# Altium schematic sheet POWER.SchDoc
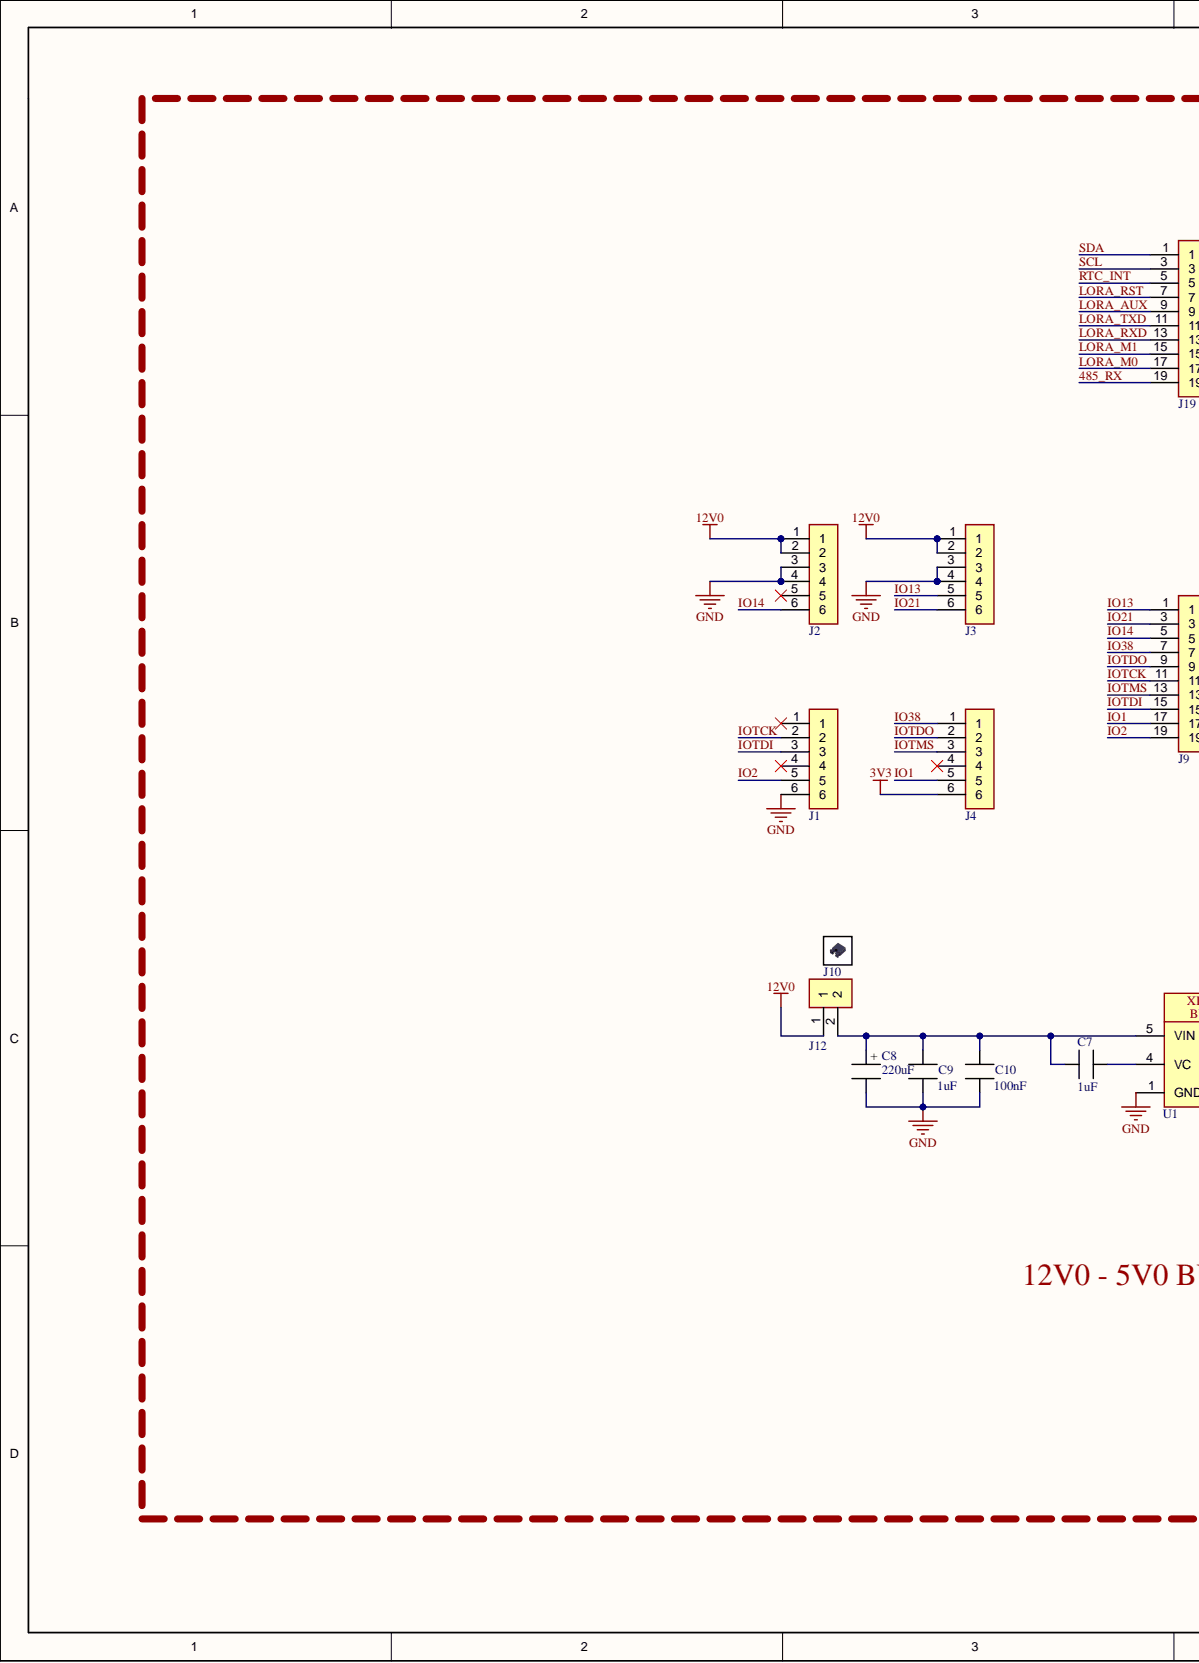
[diagram p0: POWER.SchDoc, left]
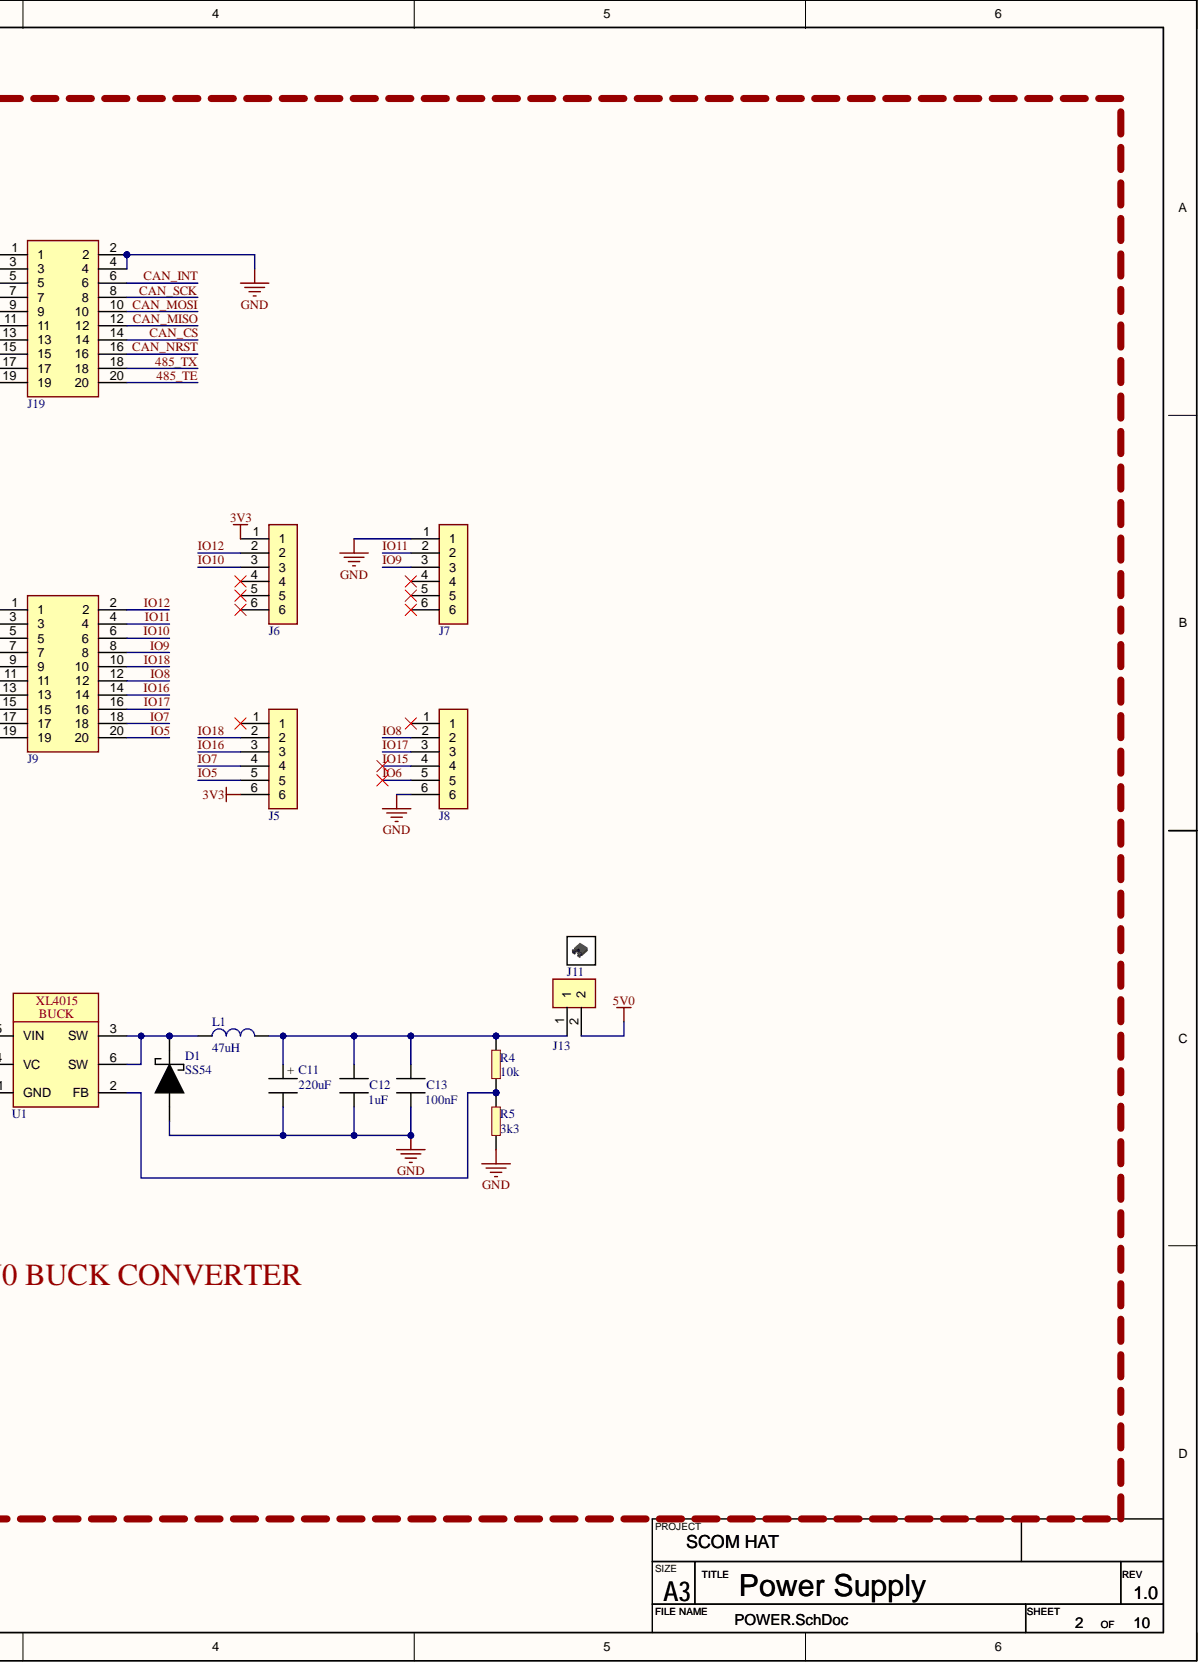
[diagram p1: POWER.SchDoc, right]

## Parts
C7  value 1uF  [CAPC2012X13L]  (hidden comment: MLCC_0805)
C8  value 220uF  [CAP_D10_H16_P5 - Flat]  (hidden comment: ERP_102-2)
C9  value 1uF  [CAPC2012X13L]  (hidden comment: MLCC_0805)
C10  value 100nF  [CAPC2012X13L]  (hidden comment: MLCC_0805)
C11  value 220uF  [CAP_D10_H16_P5 - Flat]  (hidden comment: ERP_102-2)
C12  value 1uF  [CAPC2012X13L]  (hidden comment: MLCC_0805)
C13  value 100nF  [CAPC2012X13L]  (hidden comment: MLCC_0805)
D1  value SS54  [DIOM6959X26L]  (hidden comment: ERP_104-3)
J1  6PX1R_M  [6PX1R_M_STK20]  (value not drawn)
J2  6PX1R_M  [6PX1R_M_STK20]  (value not drawn)
J3  6PX1R_M  [6PX1R_M_STK20]  (value not drawn)
J4  6PX1R_M  [6PX1R_M_STK20]  (value not drawn)
J5  6PX1R_M  [6PX1R_M_STK20]  (value not drawn)
J6  6PX1R_M  [6PX1R_M_STK20]  (value not drawn)
J7  6PX1R_M  [6PX1R_M_STK20]  (value not drawn)
J8  6PX1R_M  [6PX1R_M_STK20]  (value not drawn)
J9  20PX2R_M  [20PX2R_Male_THT_Shrouded]  (value not drawn)
J10  Jumper Cap  (value not drawn)  (mechanical)
J11  Jumper Cap  (value not drawn)  (mechanical)
J12  2PX1R  [2PX1R]  (value not drawn)
J13  2PX1R  [2PX1R]  (value not drawn)
J19  20PX2R_M  [20PX2R_Male_THT_Shrouded]  (value not drawn)
L1  value 47uH  [IND_1212]  (hidden comment: ERP_103-3)
R4  value 10k  [RES_0603]  (hidden comment: ERP_101-1)
R5  value 3k3  [RES_0603]  (hidden comment: ERP_101-1)
U1  XL4015  [XL4015]

## Nets
3V3: J4.6 J5.6 J6.1
5V0: J13.2
12V0: J2.1 J2.2 J3.1 J3.2 J12.1
GND: C8.2 C9.2 C10.2 C11.2 C12.2 C13.2 D1.2 J1.6 J2.3 J2.4 J3.3 J3.4 J7.1 J8.6 J19.2 J19.4 R5.2 U1.1(GND)
485_RX: J19.19
485_TE: J19.20
485_TX: J19.18
CAN_CS: J19.14
CAN_INT: J19.6
CAN_MISO: J19.12
CAN_MOSI: J19.10
CAN_NRST: J19.16
CAN_SCK: J19.8
IO1: J4.5 J9.17
IO2: J1.5 J9.19
IO5: J5.5 J9.20
IO6: J8.5
IO7: J5.4 J9.18
IO8: J8.2 J9.12
IO9: J7.3 J9.8
IO10: J6.3 J9.6
IO11: J7.2 J9.4
IO12: J6.2 J9.2
IO13: J3.5 J9.1
IO14: J2.6 J9.5
IO15: J8.4
IO16: J5.3 J9.14
IO17: J8.3 J9.16
IO18: J5.2 J9.10
IO21: J3.6 J9.3
IO38: J4.1 J9.7
IOTCK: J1.2 J9.11
IOTDI: J1.3 J9.15
IOTDO: J4.2 J9.9
IOTMS: J4.3 J9.13
LORA_AUX: J19.9
LORA_M0: J19.17
LORA_M1: J19.15
LORA_RST: J19.7
LORA_RXD: J19.13
LORA_TXD: J19.11
RTC_INT: J19.5
SCL: J19.3
SDA: J19.1
NetC7_1: C7.1 C8.1 C9.1 C10.1 J12.2 U1.5(VIN)
NetC7_2: C7.2 U1.4(VC)
NetC11_1: C11.1 C12.1 C13.1 J13.1 L1.2 R4.1
NetD1_1: D1.1 L1.1 U1.3(SW) U1.6(SW)
NetR4_2: R4.2 R5.1 U1.2(FB)

Not connected: J1 1 4; J2 5; J4 4; J5 1; J6 4 5 6; J7 4 5 6; J8 1

## Library data of the parts
C7 C9 C12 = MLCC_0805: 1uF 105 0805 (2012 metric) 50V X7R MLCC SMD; Component Kind: Standard; Library Name: Capacitor.SchLib; Package: 0805
C8 C11 = CAP_D10_H16_P5: Electrolytic Capacitor Through Hole D10 P5.0; Component Kind: Standard; Library Name: ElectrolyticCapacitor.SchLib; Package: 10X5
C10 C13 = MLCC_0805: Chip Capacitor, 2-Leads, Body 2.00x1.25mm, IPC High Density; Component Kind: Standard; Library Name: Capacitor.SchLib; Package: 0805
D1 = SS54: Component Kind: Standard; Library Name: Schottky.SchLib; Package: SMC
J1-J8 = 6PX1R_M: 6PX1R Male Header; Component Kind: Standard; Library Name: PinHeader.SchLib
J9 J19 = 20PX2R_M: Connector Header Surface Mount 20 position 0.100" (2.54mm); Manufacturer 1: CNC Tech; Component Kind: Standard; Library Name: PinHeader.SchLib
J10 J11 = Jumper Cap: 2 Pin Jumper Cap P2.54; Library Name: Jumper.SchLib
L1 = IND_1212: Manufacturer 1: Würth Elektronik; Component Kind: Standard; Description: IND_1212; Library Name: Inductor.SchLib
R4 R5 = RES_0603: Manufacturer Part Number 1: 560112116003; Component Kind: Standard; Description: RES_0603; Library Name: Resistor.SchLib; Package: 0603
U1 = XL4015: 5A 180KHz 36V Buck DC to DC Converter; Manufacturer 1: XLSemi; Manufacturer Part Number 1: XL4015E1; Component Kind: Standard; Library Name: DCDC_Switcher.SchLib
J12 J13 = 2PX1R: Component Kind: Standard; Description: 2PX1R; Library Name: PinHeader.SchLib
